# Altium schematic sheet ENCODER.SchDoc
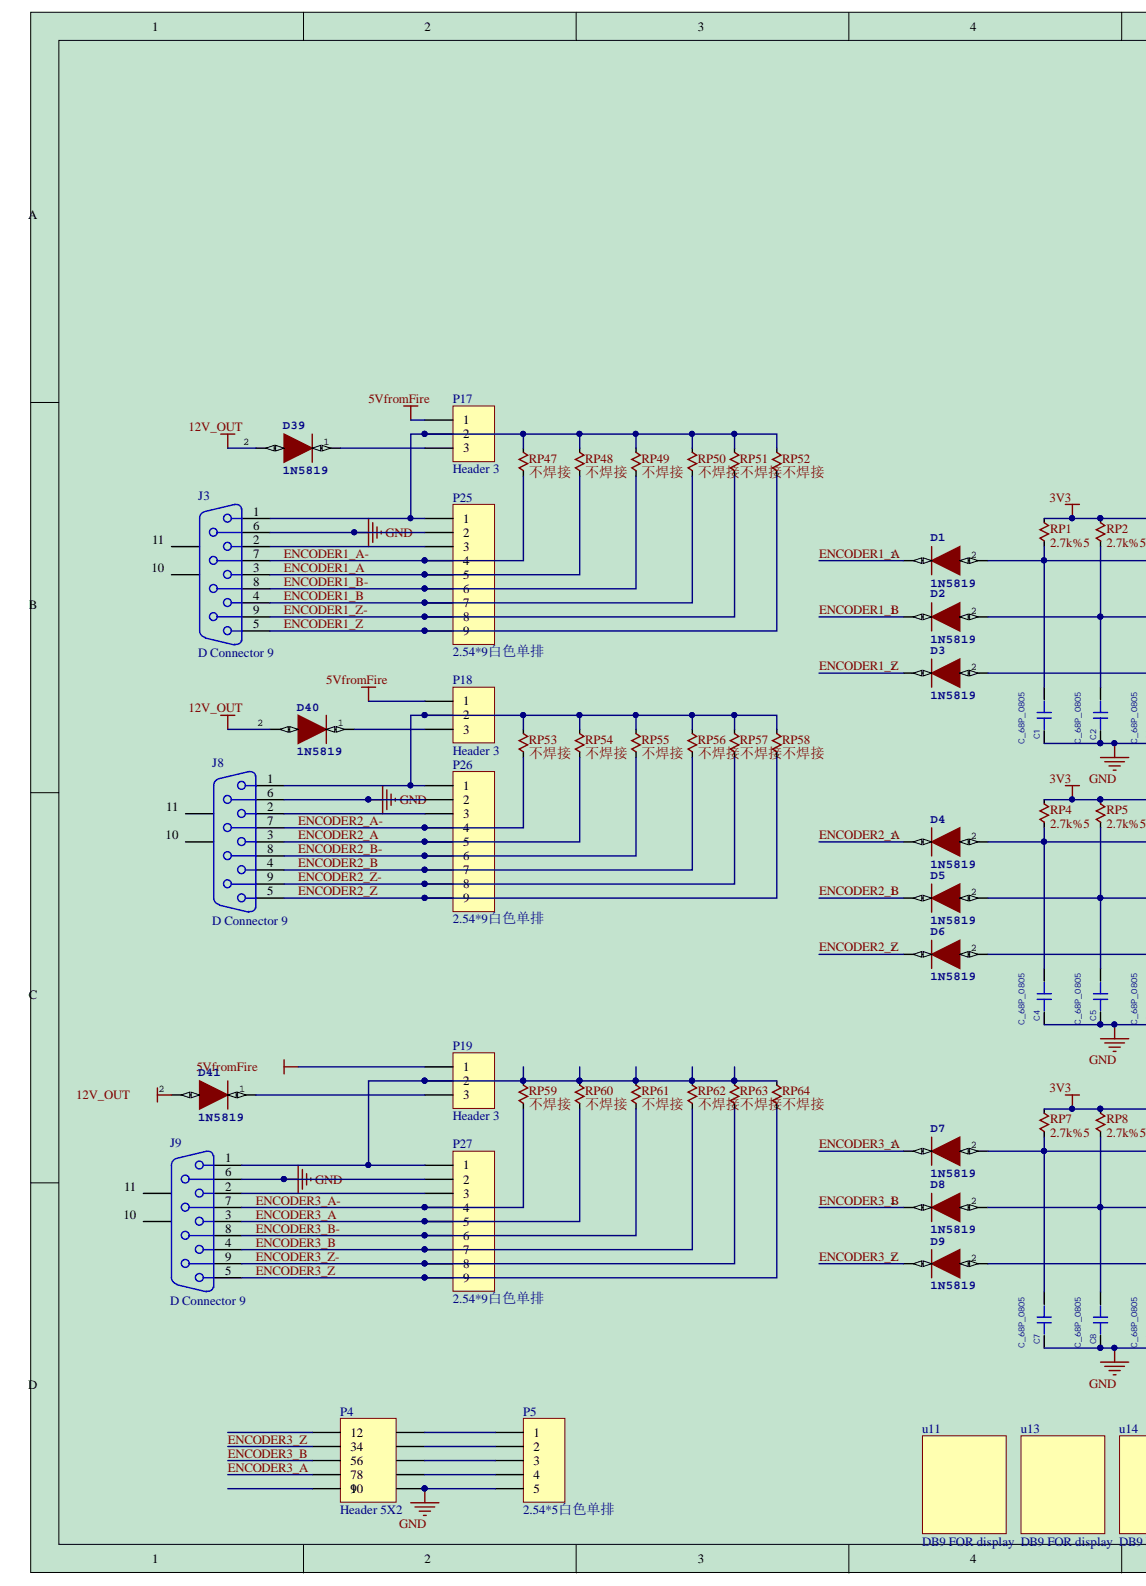
[diagram p0: ENCODER.SchDoc, left]
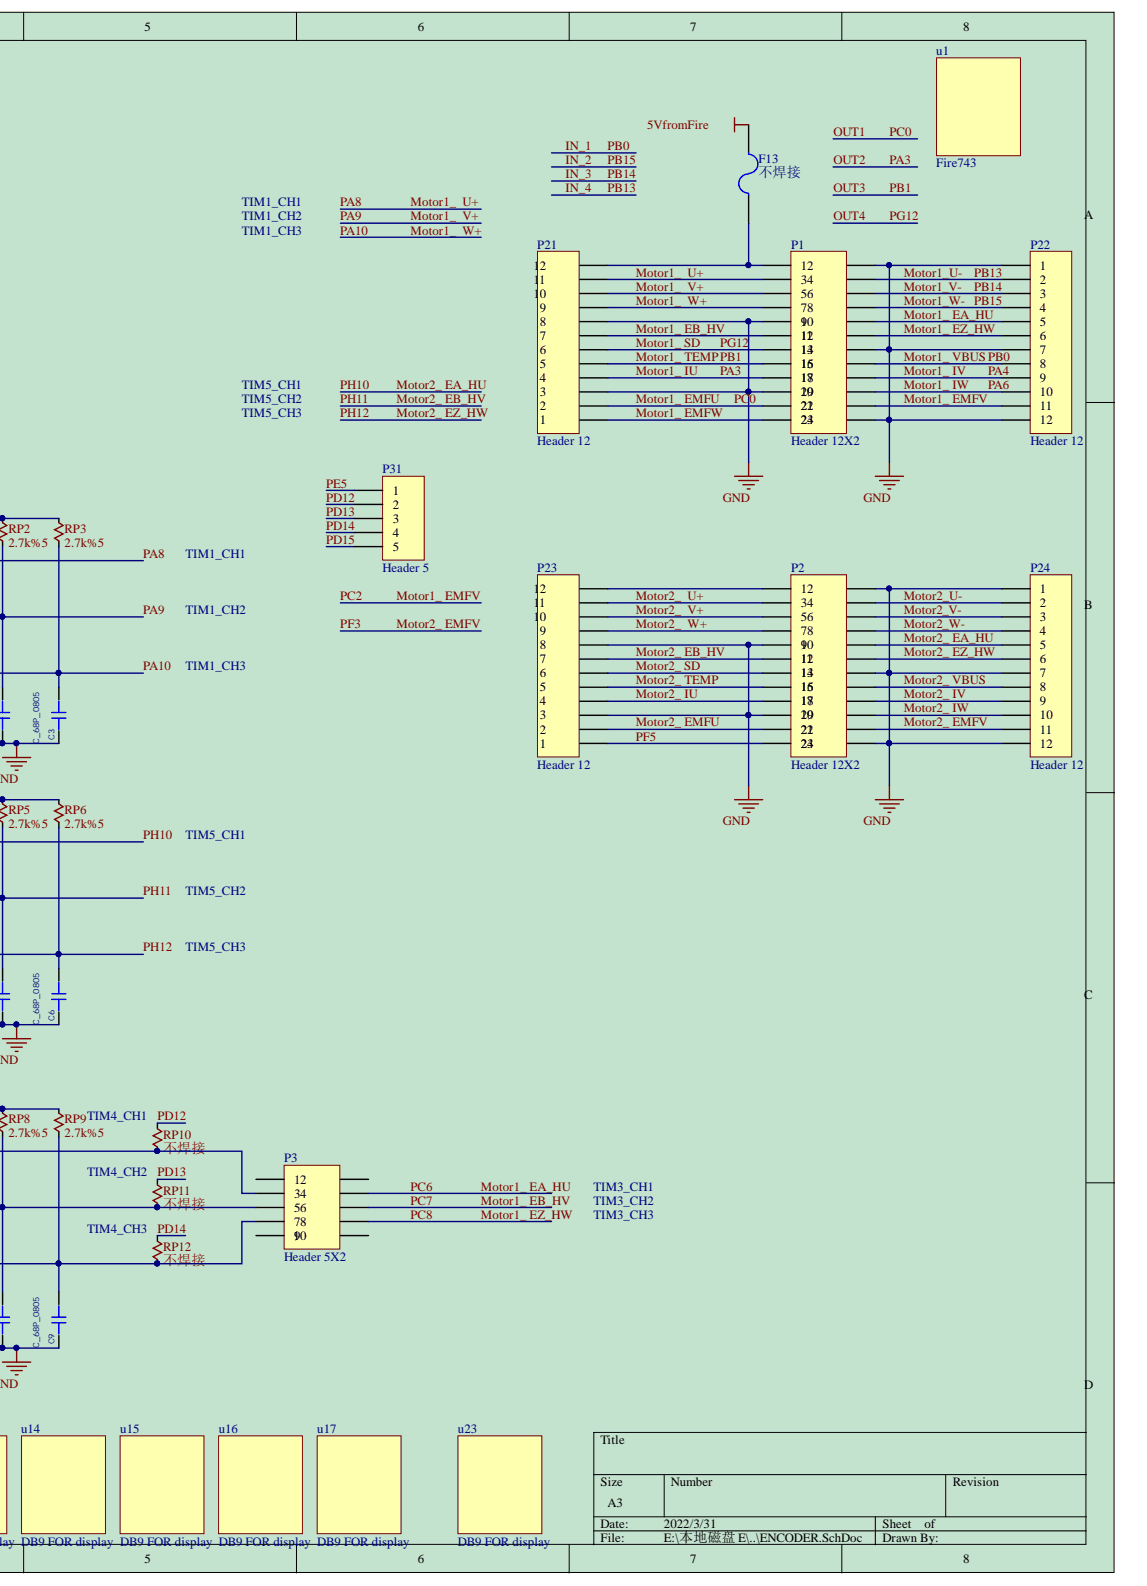
[diagram p1: ENCODER.SchDoc, right]

## Parts
C1  C_68P_0805  [cap0805]
C2  C_68P_0805  [cap0805]
C3  C_68P_0805  [cap0805]
C4  C_68P_0805  [cap0805]
C5  C_68P_0805  [cap0805]
C6  C_68P_0805  [cap0805]
C7  C_68P_0805  [cap0805]
C8  C_68P_0805  [cap0805]
C9  C_68P_0805  [cap0805]
D1  1N5819  [SOD123]
D2  1N5819  [SOD123]
D3  1N5819  [SOD123]
D4  1N5819  [SOD123]
D5  1N5819  [SOD123]
D6  1N5819  [SOD123]
D7  1N5819  [SOD123]
D8  1N5819  [SOD123]
D9  1N5819  [SOD123]
D39  1N5819  [SOD123]
D40  1N5819  [SOD123]
D41  1N5819  [SOD123]
F13  不焊接  [1206_FUSE]
J3  D Connector 9  [DB9]
J8  D Connector 9  [DB9]
J9  D Connector 9  [DB9]
P1  Header 12X2  [IDC-TH_X9555WV-2X12-PTV01]
P2  Header 12X2  [IDC-TH_X9555WV-2X12-PTV01]
P3  Header 5X2  [HDR2X5]
P4  Header 5X2  [HDR2X5]
P5  2.54*5白色单排  [2.54*5单排白座]
P17  Header 3  [HDR1X3]
P18  Header 3  [HDR1X3]
P19  Header 3  [HDR1X3]
P21  Header 12  [HDR1X12]
P22  Header 12  [HDR1X12]
P23  Header 12  [HDR1X12]
P24  Header 12  [HDR1X12]
P25  2.54*9白色单排  [CON9-2.54 - duplicate]
P26  2.54*9白色单排  [CON9-2.54 - duplicate]
P27  2.54*9白色单排  [CON9-2.54 - duplicate]
P31  Header 5  [HDR1X5]
RP1  2.7k%5  [R0805]
RP2  2.7k%5  [R0805]
RP3  2.7k%5  [R0805]
RP4  2.7k%5  [R0805]
RP5  2.7k%5  [R0805]
RP6  2.7k%5  [R0805]
RP7  2.7k%5  [R0805]
RP8  2.7k%5  [R0805]
RP9  2.7k%5  [R0805]
RP10  不焊接  [R0805]
RP11  不焊接  [R0805]
RP12  不焊接  [R0805]
RP47  不焊接  [R0805]
RP48  不焊接  [R0805]
RP49  不焊接  [R0805]
RP50  不焊接  [R0805]
RP51  不焊接  [R0805]
RP52  不焊接  [R0805]
RP53  不焊接  [R0805]
RP54  不焊接  [R0805]
RP55  不焊接  [R0805]
RP56  不焊接  [R0805]
RP57  不焊接  [R0805]
RP58  不焊接  [R0805]
RP59  不焊接  [R0805]
RP60  不焊接  [R0805]
RP61  不焊接  [R0805]
RP62  不焊接  [R0805]
RP63  不焊接  [R0805]
RP64  不焊接  [R0805]
u1  Fire743  [186*131]
u11  DB9 FOR display  [DB9 SOCKET FOR DISPLAY]
u13  DB9 FOR display  [DB9 SOCKET FOR DISPLAY]
u14  DB9 FOR display  [DB9 SOCKET FOR DISPLAY]
u15  DB9 FOR display  [DB9 SOCKET FOR DISPLAY]
u16  DB9 FOR display  [DB9 SOCKET FOR DISPLAY]
u17  DB9 FOR display  [DB9 SOCKET FOR DISPLAY]
u23  DB9 FOR display  [backup4pad]

## Nets
3V3: RP1.1 RP2.1 RP3.1 RP4.1 RP5.1 RP6.1 RP7.1 RP8.1 RP9.1
5VFROMFIRE: F13.2 P17.1 P18.1 P19.1
12V_OUT: D39.2 D40.2 D41.2
GND: C1.1 C2.1 C3.1 C4.1 C5.1 C6.1 C7.1 C8.1 C9.1 J3.6 J8.6 J9.6 P1.2 P1.9 P1.14 P1.19 P1.24 P2.2 P2.9 P2.14 P2.19 P2.24 P4.10 P5.5 P21.3 P21.8 P22.1 P22.7 P22.12 P23.3 P23.8 P24.1 P24.7 P24.12 P25.2 P26.2 P27.2
ENCODER1_A: D1.1 J3.3 P25.5 RP48.2
ENCODER1_A-: J3.7 P25.4 RP47.2
ENCODER1_B: D2.1 J3.4 P25.7 RP50.2
ENCODER1_B-: J3.8 P25.6 RP49.2
ENCODER1_Z: D3.1 J3.5 P25.9 RP52.2
ENCODER1_Z-: J3.9 P25.8 RP51.2
ENCODER2_A: D4.1 J8.3 P26.5 RP54.2
ENCODER2_A-: J8.7 P26.4 RP53.2
ENCODER2_B: D5.1 J8.4 P26.7 RP56.2
ENCODER2_B-: J8.8 P26.6 RP55.2
ENCODER2_Z: D6.1 J8.5 P26.9 RP58.2
ENCODER2_Z-: J8.9 P26.8 RP57.2
ENCODER3_A: D7.1 J9.3 P4.7 P27.5 RP60.2
ENCODER3_A-: J9.7 P27.4 RP59.2
ENCODER3_B: D8.1 J9.4 P4.5 P27.7 RP62.2
ENCODER3_B-: J9.8 P27.6 RP61.2
ENCODER3_Z: D9.1 J9.5 P4.3 P27.9 RP64.2
ENCODER3_Z-: J9.9 P27.8 RP63.2
IN_1: P1.16 P22.8
IN_2: P1.8 P22.4
IN_3: P1.6 P22.3
IN_4: P1.4 P22.2
MOTOR1_  U+: C1.2 D1.2 P1.3 P21.11 RP1.2
MOTOR1_  V+: C2.2 D2.2 P1.5 P21.10 RP2.2
MOTOR1_  W+: C3.2 D3.2 P1.7 P21.9 RP3.2
MOTOR1_ EA_HU: P1.10 P3.4 P22.5
MOTOR1_ EB_HV: P1.11 P3.6 P21.7
MOTOR1_ EMFU: P1.21 P21.2
MOTOR1_ EMFV: P1.22 P22.11
MOTOR1_ EMFW: P1.23 P21.1
MOTOR1_ EZ_HW: P1.12 P3.8 P22.6
MOTOR1_ IU: P1.17 P21.4
MOTOR1_ IV: P1.18 P22.9
MOTOR1_ IW: P1.20 P22.10
MOTOR1_ SD: P1.13 P21.6
MOTOR1_ TEMP: P1.15 P21.5
MOTOR2_  U+: P2.3 P23.11
MOTOR2_  V+: P2.5 P23.10
MOTOR2_  W+: P2.7 P23.9
MOTOR2_ EA_HU: C4.2 D4.2 P2.10 P24.5 RP4.2
MOTOR2_ EB_HV: C5.2 D5.2 P2.11 P23.7 RP5.2
MOTOR2_ EMFU: P2.21 P23.2
MOTOR2_ EMFV: P2.22 P24.11
MOTOR2_ EZ_HW: C6.2 D6.2 P2.12 P24.6 RP6.2
MOTOR2_ IU: P2.17 P23.4
MOTOR2_ IV: P2.18 P24.9
MOTOR2_ IW: P2.20 P24.10
MOTOR2_ SD: P2.13 P23.6
MOTOR2_ TEMP: P2.15 P23.5
MOTOR2_ VBUS: P2.16 P24.8
MOTOR2_U-: P2.4 P24.2
MOTOR2_V-: P2.6 P24.3
MOTOR2_W-: P2.8 P24.4
PD12: P31.2 RP10.2
PD13: P31.3 RP11.2
PD14: P31.4 RP12.2
PD15: P31.5
PE5: P31.1
PF5: P2.23 P23.1
NetC7_2: C7.2 D7.2 P3.3 RP7.2 RP10.1
NetC8_2: C8.2 D8.2 P3.5 RP8.2 RP11.1
NetC9_2: C9.2 D9.2 P3.7 RP9.2 RP12.1
NetD39_1: D39.1 P17.3
NetD40_1: D40.1 P18.3
NetD41_1: D41.1 P19.3
NetF13_1: F13.1 P1.1 P21.12
NetJ3_1: J3.1 P17.2 P25.1 RP47.1 RP48.1 RP49.1 RP50.1 RP51.1 RP52.1
NetJ3_2: J3.2 P25.3
NetJ8_1: J8.1 P18.2 P26.1 RP53.1 RP54.1 RP55.1 RP56.1 RP57.1 RP58.1
NetJ8_2: J8.2 P26.3
NetJ9_1: J9.1 P19.2 P27.1 RP59.1 RP60.1 RP61.1 RP62.1 RP63.1 RP64.1
NetJ9_2: J9.2 P27.3
NetP2_1: P2.1 P23.12
NetP4_2: P4.2 P5.1
NetP4_4: P4.4 P5.2
NetP4_6: P4.6 P5.3
NetP4_8: P4.8 P5.4

Not connected: J3 10 11; J8 10 11; J9 10 11; P3 1 2 9 10; P4 1 9

## Library data of the parts
C1-C9 = C_22P_0603: PCB DECAL: C_0603; Component Kind: Standard
D1-D9 D39-D41 = 1N5819: Manufacturer_Name: STMicroelectronics; Component Kind: Standard; Height: mm; Library Name: Schlib1.SchLib; Manufacturer_Part_Number: 1N5819; Mouser_Part_Number: 511-1N5819; Mouser_Price-Stock: https://www.mouser.co.uk/ProductDetail/STMicroelectronics/1N5819?qs=G5AQjGfRJcKKUy9S%252BaTHRQ%3D%3D
F13 = Fuse 2: Fuse; Component Kind: Standard; LatestRevisionDate: 17-Jul-2002; LatestRevisionNote: Re-released for DXP Platform.; PackageReference: PIN-W2/E2.8; Published: 8-Jun-2000; Simulation: FUSE; Library Name: jinrylib.IntLib; Publisher: Altium Limited
J3 J8 J9 = D Connector 9: Receptacle Assembly, 9 Position, Right Angle; PackageDocument: O; Positions: 9; Component Kind: Standard; DatasheetDocument: 1-Sep-1999; Gender: Receptacle; LatestRevisionDate: 17-Jul-2002; LatestRevisionNote: Re-released for DXP Platform.; Library Name: Miscellaneous Connectors.IntLib; Orientation: Right Angle; PackageReference: 788750; Published: 15-Jun-2001; Signal Integrity: Connector; Publisher: Altium Limited
P1 P2 = Header 12X2: Header, 12-Pin, Dual row; Component Kind: Standard; LatestRevisionDate: 17-Jul-2002; LatestRevisionNote: Re-released for DXP Platform.; Library Name: Miscellaneous Connectors.IntLib; Signal Integrity: Connector; Publisher: Altium Limited
P3 P4 = Header 5X2: Header, 5-Pin, Dual row; Component Kind: Standard; LatestRevisionDate: 17-Jul-2002; LatestRevisionNote: Re-released for DXP Platform.; Library Name: Miscellaneous Connectors.IntLib; Signal Integrity: Connector; Publisher: Altium Limited
P5 P31 = Header 5: Header, 5-Pin; Component Kind: Standard; LatestRevisionDate: 17-Jul-2002; LatestRevisionNote: Re-released for DXP Platform.; Library Name: Miscellaneous Connectors.IntLib; Signal Integrity: Connector; Publisher: Altium Limited
P17-P19 = Header 3: Header, 3-Pin; Component Kind: Standard; LatestRevisionDate: 17-Jul-2002; LatestRevisionNote: Re-released for DXP Platform.; Library Name: Miscellaneous Connectors.IntLib; Signal Integrity: Connector; Publisher: Altium Limited
P21-P24 = Header 12: Header, 12-Pin; Component Kind: Standard; LatestRevisionDate: 17-Jul-2002; LatestRevisionNote: Re-released for DXP Platform.; Library Name: Miscellaneous Connectors.IntLib; Signal Integrity: Connector; Publisher: Altium Limited
P25-P27 = Header 9: Header, 9-Pin; Component Kind: Standard; LatestRevisionDate: 17-Jul-2002; LatestRevisionNote: Re-released for DXP Platform.; Library Name: Miscellaneous Connectors.IntLib; Publisher: Altium Limited
RP1-RP12 RP47-RP64 = RESISTOR: Generic Resistor; Value: 4.7K; Component Kind: Standard; Library Name: Digilent.IntLib
u11 u13-u17 u23 = Fire743: Component Kind: Standard; Library Name: Schlib1.SchLib
u1 = Fire743: Component Kind: Standard; Library Name: Schlib1.SchLib
